# Altium schematic sheet 工程车主控V2.0.SchDoc(工程车主控V2.0)
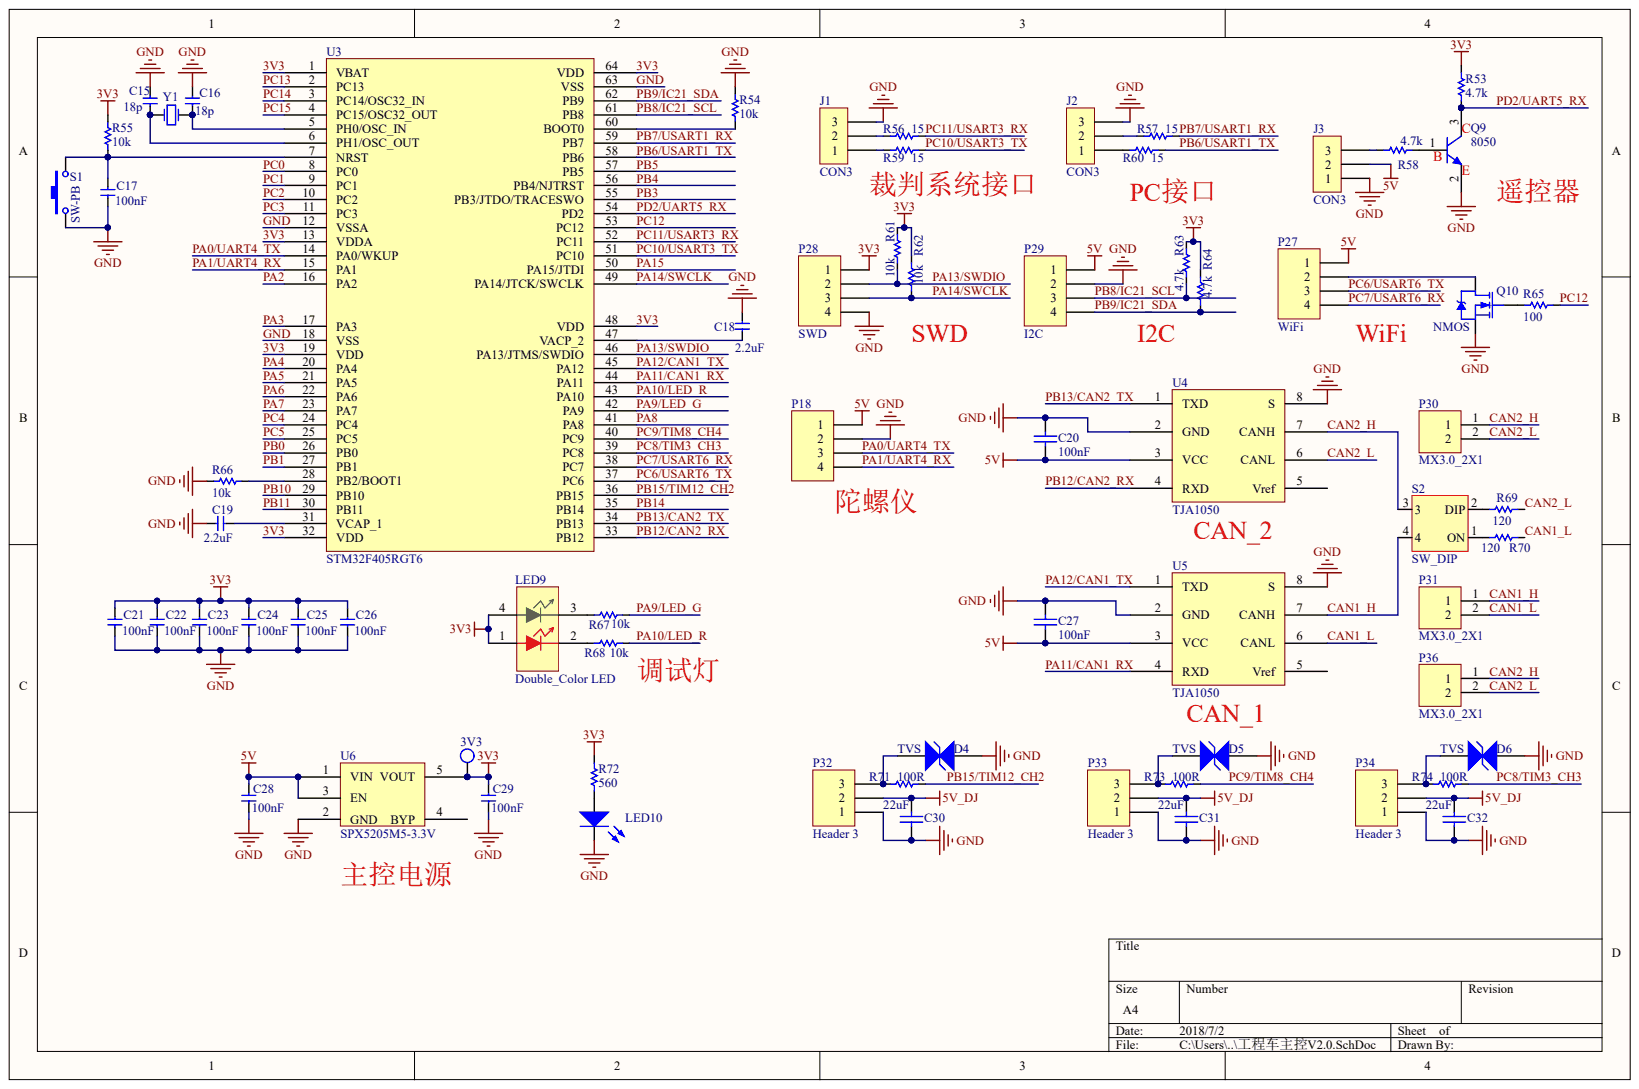
[diagram p0: 工程车主控V2.0.SchDoc]

## Parts
3V3  TP  [TP_SMT]  (value not drawn)
C15  18p  [CAPC1608N]
C16  18p  [CAPC1608N]
C17  100nF  [CAPC1608N]
C18  2.2uF  [CAPC1608N]
C19  2.2uF  [CAPC1608N]
C20  100nF  [0603C]
C21  100nF  [CAPC1608N]
C22  100nF  [CAPC1608N]
C23  100nF  [CAPC1608N]
C24  100nF  [CAPC1608N]
C25  100nF  [CAPC1608N]
C26  100nF  [CAPC1608N]
C27  100nF  [0603C]
C28  100nF  [0603C]
C29  100nF  [0603C]
C30  value 22uF  [0603C]  (hidden comment: 1uF/0603/50V)
C31  value 22uF  [0603C]  (hidden comment: 1uF/0603/50V)
C32  value 22uF  [0603C]  (hidden comment: 1uF/0603/50V)
D4  TVS  [SOD-323]
D5  TVS  [SOD-323]
D6  TVS  [SOD-323]
J1  CON3  [HY2.00MM 3x1立式]
J2  CON3  [HY2.00MM 3x1立式]
J3  CON3  [HY2.00MM 3x1立式]
LED9  Double_Color LED  [0805LEDX2]
LED10  LED_RED  [0603LED_R]  (value not drawn)
P18  (no value)  [HY2.00MM 4X1立式]
P27  WiFi  [HY2.00MM 4X1立式]
P28  SWD  [HEADER 4x1]
P29  I2C  [HY2.00MM 4X1立式]
P30  MX3.0_2X1  [MX3.0MM 2X1AW]
P31  MX3.0_2X1  [MX3.0MM 2X1AW]
P32  Header 3  [HY2.00MM 3x1立式]
P33  Header 3  [HY2.00MM 3x1立式]
P34  Header 3  [HY2.00MM 3x1立式]
P36  MX3.0_2X1  [MX3.0MM 2X1AW]
Q9  8050  [SOT-23-3]
Q10  NMOS  [SOT-23-3]
R53  4.7k  [0603R]
R54  10k  [RESC1608N]
R55  10k  [RESC1608N]
R56  15  [0603R]
R57  15  [0603R]
R58  4.7k  [0603R]
R59  15  [0603R]
R60  15  [0603R]
R61  10k  [0603R]
R62  10k  [0603R]
R63  4.7k  [0603R]
R64  4.7k  [0603R]
R65  100  [0603R]
R66  10k  [RESC1608N]
R67  10k  [0603R]
R68  10k  [0603R]
R69  120  [0603R]
R70  120  [0603R]
R71  100R  [0603R]
R72  560  [0603R]
R73  100R  [0603R]
R74  100R  [0603R]
S1  SW-PB  [SW 3mmx4mm]
S2  SW_DIP  [SW_DIP_2X2]
U3  STM32F405RGT6  [LQFP_64]
U4  TJA1050  [D8_NoThermal]
U5  TJA1050  [D8_NoThermal]
U6  SPX5205M5-3.3V  [SOT-23-5 L]
Y1  XTAL  [NX5032GA]  (value not drawn)

## Nets
5V: C20.1 C27.1 C28.2 J3.2 P18.1 P27.1 P29.1 U4.3(VCC) U5.3(VCC) U6.1(VIN) U6.3(EN)
5V_DJ: C30.2 C31.2 C32.2 P32.2 P33.2 P34.2
3V3: 3V3.1 C21.2 C22.2 C23.2 C24.2 C25.2 C26.2 C29.2 LED9.1 LED9.4 P28.1 R53.2 R55.2 R61.2 R62.2 R63.2 R64.2 R72.2 U3.1(VBAT) U3.13(VDDA) U3.19(VDD) U3.32(VDD) U3.48(VDD) U3.64(VDD) U6.5(VOUT)
CAN1_H: P31.1 S2.4 U5.7(CANH)
CAN1_L: P31.2 R70.2 U5.6(CANL)
CAN2_H: P30.1 P36.1 S2.3 U4.7(CANH)
CAN2_L: P30.2 P36.2 R69.1 U4.6(CANL)
GND: C15.2 C16.1 C17.1 C18.1 C19.1 C20.2 C21.1 C22.1 C23.1 C24.1 C25.1 C26.1 C27.2 C28.1 C29.1 C30.1 C31.1 C32.1 D4.1 D5.1 D6.1 J1.3 J2.3 J3.1 LED10.2 P18.2 P28.4 P29.2 P32.1 P33.1 P34.1 Q9.2 Q10.2 R54.2 R66.1 S1.1 U3.12(VSSA) U3.18(VSS) U3.63(VSS) U4.2(GND) U4.8(S) U5.2(GND) U5.8(S) U6.2(GND)
PA0/UART4_TX: P18.3 U3.14(PA0/WKUP)
PA1/UART4_RX: P18.4 U3.15(PA1)
PA2: U3.16(PA2)
PA3: U3.17(PA3)
PA4: U3.20(PA4)
PA5: U3.21(PA5)
PA6: U3.22(PA6)
PA7: U3.23(PA7)
PA8: U3.41(PA8)
PA9/LED_G: R67.1 U3.42(PA9)
PA10/LED_R: R68.1 U3.43(PA10)
PA11/CAN1_RX: U3.44(PA11) U5.4(RXD)
PA12/CAN1_TX: U3.45(PA12) U5.1(TXD)
PA13/SWDIO: P28.2 R61.1 U3.46(PA13/JTMS/SWDIO)
PA14/SWCLK: P28.3 R62.1 U3.49(PA14/JTCK/SWCLK)
PA15: U3.50(PA15/JTDI)
PB0: U3.26(PB0)
PB1: U3.27(PB1)
PB3: U3.55(PB3/JTDO/TRACESWO)
PB4: U3.56(PB4/NJTRST)
PB5: U3.57(PB5)
PB6/USART1_TX: R60.2 U3.58(PB6)
PB7/USART1_RX: R57.2 U3.59(PB7)
PB8/IC21_SCL: P29.3 R63.1 U3.61(PB8)
PB9/IC21_SDA: P29.4 R64.1 U3.62(PB9)
PB10: U3.29(PB10)
PB11: U3.30(PB11)
PB12/CAN2_RX: U3.33(PB12) U4.4(RXD)
PB13/CAN2_TX: U3.34(PB13) U4.1(TXD)
PB14: U3.35(PB14)
PB15/TIM12_CH2: R71.1 U3.36(PB15)
PC0: U3.8(PC0)
PC1: U3.9(PC1)
PC2: U3.10(PC2)
PC3: U3.11(PC3)
PC4: U3.24(PC4)
PC5: U3.25(PC5)
PC6/USART6_TX: P27.3 U3.37(PC6)
PC7/USART6_RX: P27.4 U3.38(PC7)
PC8/TIM3_CH3: R74.1 U3.39(PC8)
PC9/TIM8_CH4: R73.1 U3.40(PC9)
PC10/USART3_TX: R59.2 U3.51(PC10)
PC11/USART3_RX: R56.2 U3.52(PC11)
PC12: R65.2 U3.53(PC12)
PC13: U3.2(PC13)
PC14: U3.3(PC14/OSC32_IN)
PC15: U3.4(PC15/OSC32_OUT)
PD2/UART5_RX: Q9.3 R53.1 U3.54(PD2)
NetC15_1: C15.1 U3.6(PH1/OSC_OUT) Y1.1
NetC16_2: C16.2 U3.5(PH0/OSC_IN) Y1.2
NetC17_2: C17.2 R55.1 S1.2 U3.7(NRST)
NetC18_2: C18.2 U3.47(VACP_2)
NetC19_2: C19.2 U3.31(VCAP_1)
NetD4_2: D4.2 P32.3 R71.2
NetD5_2: D5.2 P33.3 R73.2
NetD6_2: D6.2 P34.3 R74.2
NetJ1_1: J1.1 R59.1
NetJ1_2: J1.2 R56.1
NetJ2_1: J2.1 R60.1
NetJ2_2: J2.2 R57.1
NetJ3_3: J3.3 R58.2
NetLED9_2: LED9.2 R68.2
NetLED9_3: LED9.3 R67.2
NetLED10_1: LED10.1 R72.1
NetP27_2: P27.2 Q10.3
NetQ9_1: Q9.1 R58.1
NetQ10_1: Q10.1 R65.1
NetR54_1: R54.1 U3.60(BOOT0)
NetR66_2: R66.2 U3.28(PB2/BOOT1)
NetR69_2: R69.2 S2.2(DIP)
NetR70_1: R70.1 S2.1(ON)

Not connected: U4 5(Vref); U5 5(Vref); U6 4(BYP)

## Library data of the parts
3V3 = TP: Test Point; Component Kind: Standard; Library Name: MyComponents.SchLib
C15-C19 C21-C26 C28 C29 = Cap: Component Kind: Standard; Library Name: WolferWu.SchLib
  C15 C16: Value: 18p
  C17 C21-C26 C28 C29: Value: 100nF
  C18 C19: Value: 2.2uF
C20 C27 = Cap: Capacitor; Value: 100pF; Component Kind: Standard; LatestRevisionDate: 17-Jul-2002; LatestRevisionNote: Re-released for DXP Platform.; Library Name: MyComponents.SchLib; PackageDescription: Radial Cap, Thru-Hole; 2 Leads; 0.3 in Pin Spacing; PackageReference: RAD-0.3; Published: 8-Jun-2000; Signal Integrity: Cap; Simulation: CAP; Publisher: Altium Limited
C30-C32 = Cap: Capacitor; Component Kind: Standard; LatestRevisionDate: 17-Jul-2002; LatestRevisionNote: Re-released for DXP Platform.; Library Name: MyComponents.SchLib; PackageDescription: Radial Cap, Thru-Hole; 2 Leads; 0.3 in Pin Spacing; PackageReference: RAD-0.3; Published: 8-Jun-2000; Signal Integrity: Cap; Simulation: CAP; Publisher: Altium Limited
LED10 = LED: Typical RED, GREEN, YELLOW, AMBER GaAs LED; Component Kind: Standard; LatestRevisionDate: 17-Jul-2002; LatestRevisionNote: Re-released for DXP Platform.; Library Name: MyComponents.SchLib; PackageDescription: SM LED; 2 Flat Leads; PackageReference: 3.2X1.6X1.1; PackageVersion: Feb-2002; Published: 8-Jun-2000; Simulation: LED2; Publisher: Altium Limited
P18 P27-P29 = Header 4: Header, 4-Pin; Component Kind: Standard; LatestRevisionDate: 17-Jul-2002; LatestRevisionNote: Re-released for DXP Platform.; Library Name: Miscellaneous Connectors.IntLib; Signal Integrity: Connector; Publisher: Altium Limited
P32-P34 = Header 3: Header, 3-Pin; Component Kind: Standard; LatestRevisionDate: 17-Jul-2002; LatestRevisionNote: Re-released for DXP Platform.; Library Name: Miscellaneous Connectors.IntLib; Signal Integrity: Connector; Publisher: Altium Limited
Q9 = 8050(NPN)SMT: NPN 贴片; Code_JEDEC: TO-226-AA; Component Kind: Standard; LatestRevisionDate: 17-Jul-2002; LatestRevisionNote: Re-released for DXP Platform.; Library Name: MyComponents.SchLib; PackageDescription: TO, Flat Index; 3 In-Line, Axial Leads; Body Dia. 4.8mm; Leads 0.55 x 0.51 mm (max); PackageReference: TO-226-AA; Published: 8-Jun-2000; Signal Integrity: NPN; Simulation: NPN; Publisher: Altium Limited
Q10 = NMOS_3p: N-Channel Power MOSFET; Note: Pin 2 is electrically connected to pin 4.; Component Kind: Standard; ComponentLink1Description: Manufacturer Link; ComponentLink1URL: http://www.irf.com/; DatasheetVersion: PD-9.814; LatestRevisionDate: 17-Jul-2002; LatestRevisionNote: Re-released for DXP Platform.; Library Name: MyComponents.SchLib; PackageDescription: TO, Thru-Hole, Vertical, Heatsink Mounted; 3 In-Line Leads; Pitch 2.54 mm; PackageReference: TO-220AB; PackageVersion: Feb-1997; Published: 8-Jun-2000; Simulation: IRF1010; Publisher: Altium Limited
R53 R56-R65 R67-R74 = Res1: Resistor; Value: 1K; Component Kind: Standard; LatestRevisionDate: 17-Jul-2002; LatestRevisionNote: Re-released for DXP Platform.; Library Name: MyComponents.SchLib; PackageDescription: Axial Device, Thru-Hole; 2 Leads; 0.3 in Pin Spacing; PackageReference: AXIAL-0.3; Published: 8-Jun-2000; Signal Integrity: Res; Simulation: RESISTOR; Publisher: Altium Limited
R54 R55 R66 = Res: Component Kind: Standard; Description: Res; Vaule: 10k; Library Name: WolferWu.SchLib
S1 = SW-PB: Switch; Component Kind: Standard; LatestRevisionDate: 17-Jul-2002; LatestRevisionNote: Re-released for DXP Platform.; Library Name: Miscellaneous Devices.IntLib; PackageDescription: Switch; 2 Leads; PackageReference: SPST-2; Published: 24-Mar-1999; Publisher: Altium Limited
Y1 = XTAL: Crystal Oscillator; Component Kind: Standard; LatestRevisionDate: 17-Jul-2002; LatestRevisionNote: Re-released for DXP Platform.; Library Name: MyComponents.SchLib; PackageDescription: Crystal, Thru-Hole; 2 Leads; Body 3.1 x 8.2 mm (Dia.xH); PackageReference: R38; Published: 8-Jun-2000; Simulation: XTAL; Publisher: Altium Limited
D4-D6 = TVS: Component Kind: Standard; Library Name: MyComponents.SchLib
J1-J3 = CON3: Component Kind: Standard; Library Name: MyComponents.SchLib
LED9 = Double_Color LED: Component Kind: Standard; Library Name: MyComponents.SchLib
P30 P31 P36 = MX3.0_2X1: Component Kind: Standard; Library Name: MyComponents.SchLib
S2 = SW_DIP: Component Kind: Standard; Library Name: MyComponents.SchLib
U3 = STM32F405RGT6: Component Kind: Standard; Description: STM32F405RGT6; Library Name: MyComponents.SchLib
U4 U5 = TJA1050: Component Kind: Standard; Library Name: MyComponents.SchLib
U6 = SPX5205M5-3.3V: Component Kind: Standard; Library Name: MyComponents.SchLib
